# Altium schematic sheet 02_OCDS.SchDoc(02_OCDS)
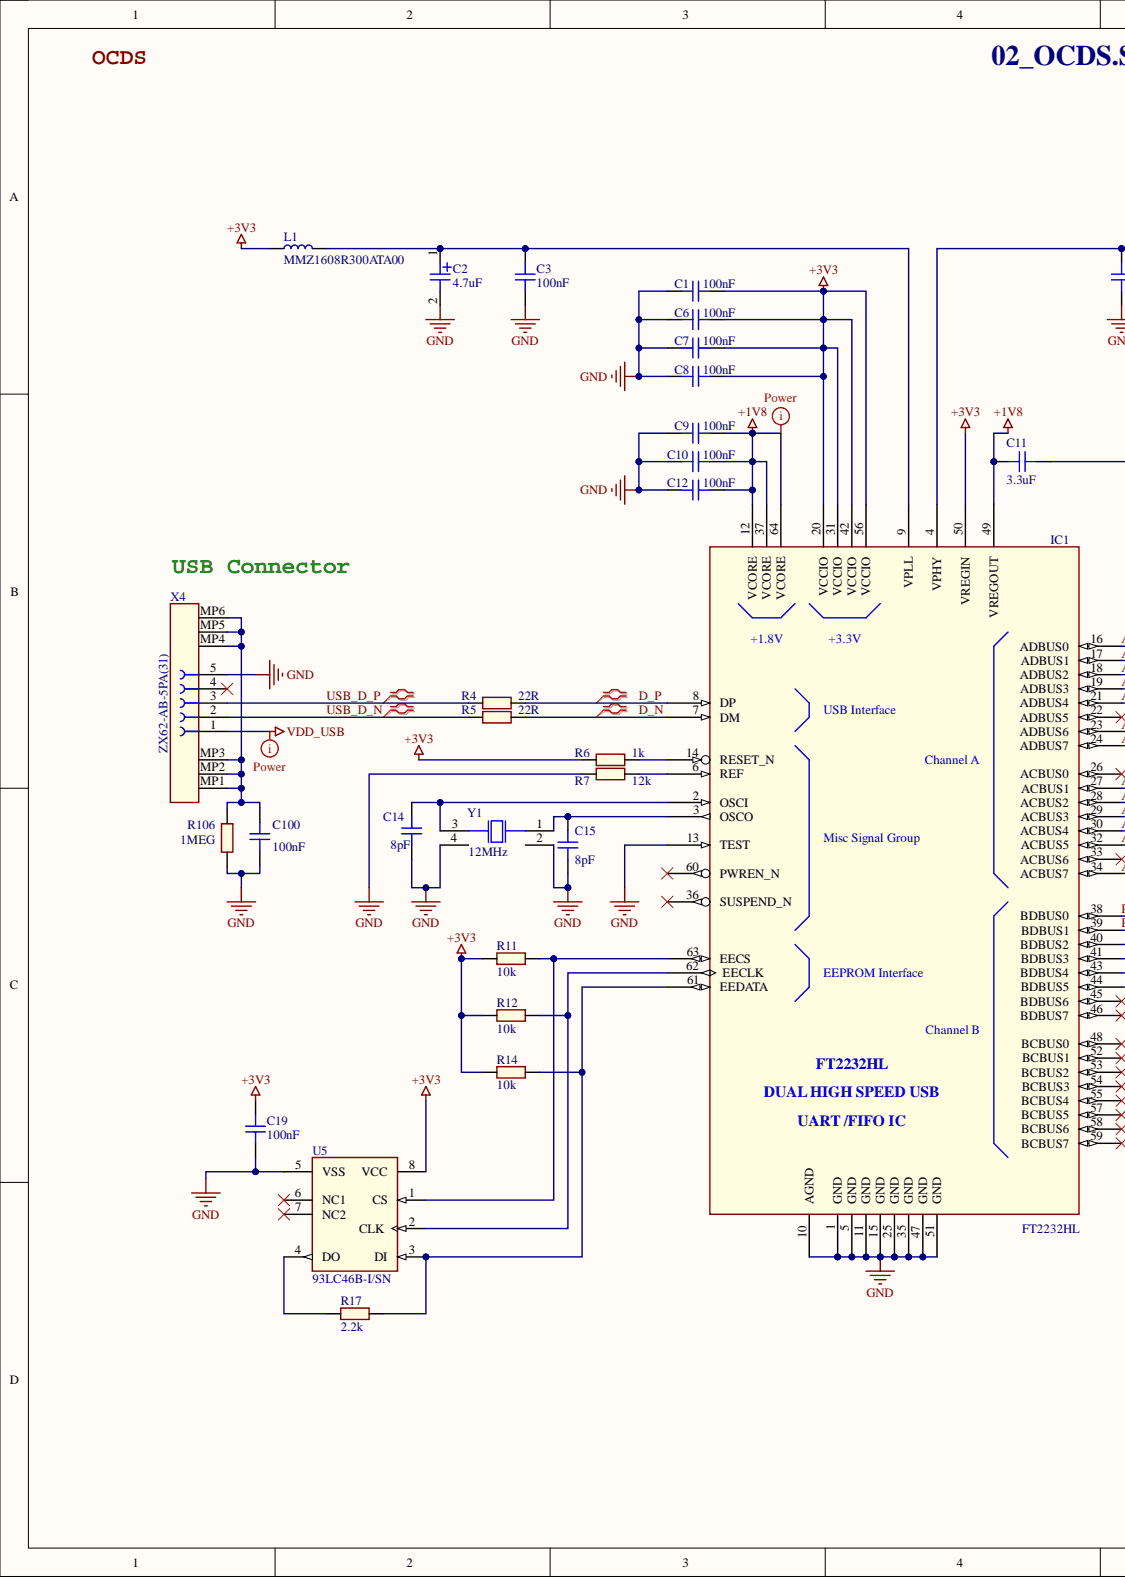
[diagram p0: 02_OCDS.SchDoc, left]
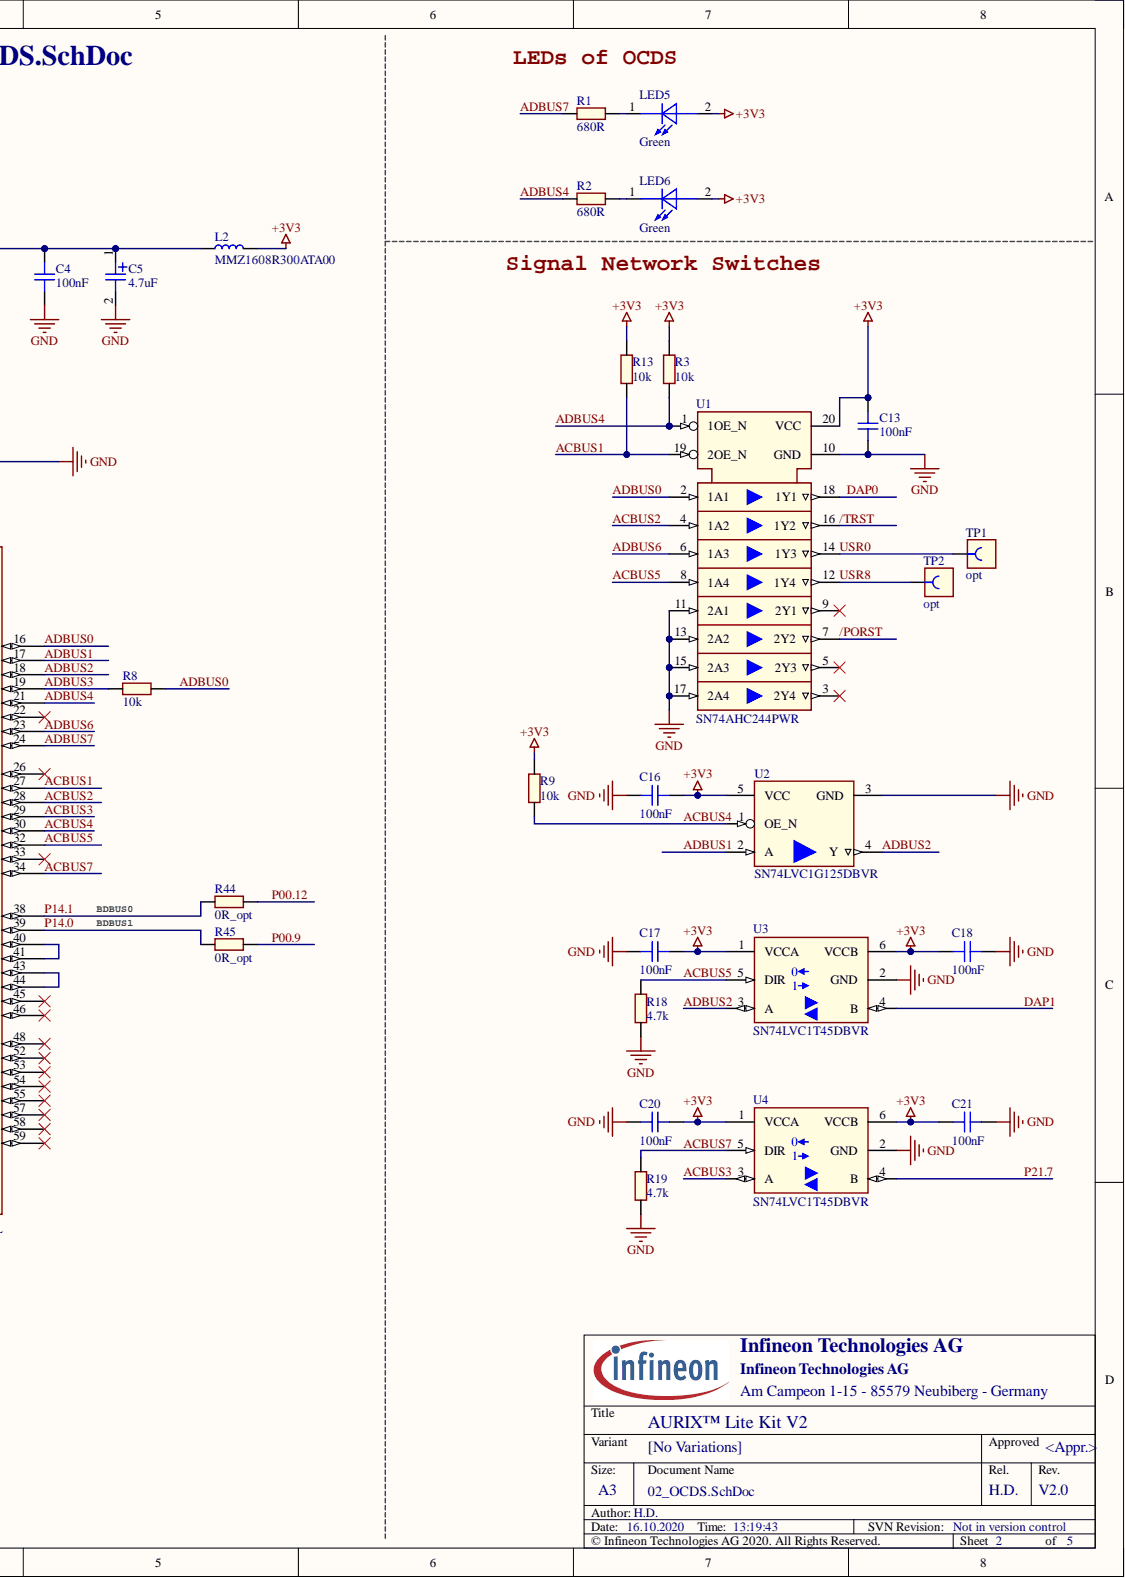
[diagram p1: 02_OCDS.SchDoc, right]

## Parts
C1  100nF  [CAPC1005X55N-1]
C2  4.7uF  [CAPMP3216X180N]
C3  100nF  [CAPC1005X55N-1]
C4  100nF  [CAPC1005X55N-1]
C5  4.7uF  [CAPMP3216X180N]
C6  100nF  [CAPC1005X55N-1]
C7  100nF  [CAPC1005X55N-1]
C8  100nF  [CAPC1005X55N-1]
C9  100nF  [CAPC1005X55N-1]
C10  100nF  [CAPC1005X55N-1]
C11  3.3uF  [CAPC2013X95N]
C12  100nF  [CAPC1005X55N-1]
C13  100nF  [CAPC1005X55N-1]
C14  8pF  [CAPC1005X55N-0]
C15  8pF  [CAPC1005X55N-0]
C16  100nF  [CAPC1005X55N-1]
C17  100nF  [CAPC1005X55N-1]
C18  100nF  [CAPC1005X55N-1]
C19  100nF  [CAPC1005X55N-1]
C20  100nF  [CAPC1005X55N-1]
C21  100nF  [CAPC1005X55N-1]
C100  100nF  [CAPC1005X55N-1]
IC1  FT2232HL  [QFP50P1200X1200X160-64N]
L1  MMZ1608R300ATA00  [INDC1608X95N_MMZ1608]
L2  MMZ1608R300ATA00  [INDC1608X95N_MMZ1608]
LED5  Green  [LED-SMD-LG L29K-XXXX-24]
LED6  Green  [LED-SMD-LG L29K-XXXX-24]
R1  680R  [RESC1608X55N-1]
R2  680R  [RESC1608X55N-1]
R3  10k  [RESC1005X40N]
R4  22R  [RESC1005X40N]
R5  22R  [RESC1005X40N]
R6  1k  [RESC1005X40N-1]
R7  12k  [RESC1005X40N]
R8  10k  [RESC1005X40N]
R9  10k  [RESC1005X40N]
R11  10k  [RESC1005X40N]
R12  10k  [RESC1005X40N]
R13  10k  [RESC1005X40N]
R14  10k  [RESC1005X40N]
R17  2.2k  [RESC1005X40N]
R18  4.7k  [RESC1005X40N-1]
R19  4.7k  [RESC1005X40N-1]
R44  0R_opt  [RESC1609X50N]
R45  0R_opt  [RESC1609X50N]
R106  1MEG  [RESC1005X40N]
TP1  opt  [TPCW100D120H460B]
TP2  opt  [TPCW100D120H460B]
U1  SN74AHC244PWR  [SOP65P640X120-20N]
U2  SN74LVC1G125DBVR  [SOT95P280X145-5N-2]
U3  SN74LVC1T45DBVR  [SOT95P280X145-6N-6]
U4  SN74LVC1T45DBVR  [SOT95P280X145-6N-6]
U5  93LC46B-I/SN  [SOIC127P600X175-8N-1]
X4  ZX62-AB-5PA(31)  Part Type: Connector  Style: USB  [CON-USB-SMD-ZX62-AB-5PA(31)]
Y1  12MHz  [XTAL-SMD-NX3225SA]

## Nets
+1V8: C9.2 C10.2 C11.2 C12.2 IC1.12(VCORE) IC1.37(VCORE) IC1.49(VREGOUT) IC1.64(VCORE)
+3V3: C1.2 C6.2 C7.2 C8.2 C13.2 C16.2 C17.2 C18.2 C19.2 C20.2 C21.2 IC1.20(VCCIO) IC1.31(VCCIO) IC1.42(VCCIO) IC1.50(VREGIN) IC1.56(VCCIO) L1.1 L2.2 LED5.2 LED6.2 R3.2 R6.2 R9.2 R11.2 R12.2 R13.2 R14.2 U1.20(VCC) U2.5(VCC) U3.1(VCCA) U3.6(VCCB) U4.1(VCCA) U4.6(VCCB) U5.8(VCC)
GND: C1.1 C2.2 C3.1 C4.1 C5.2 C6.1 C7.1 C8.1 C9.1 C10.1 C11.1 C12.1 C13.1 C14.2 C15.2 C16.1 C17.1 C18.1 C19.1 C20.1 C21.1 C100.1 IC1.1(GND) IC1.5(GND) IC1.10(AGND) IC1.11(GND) IC1.13(TEST) IC1.15(GND) IC1.25(GND) IC1.35(GND) IC1.47(GND) IC1.51(GND) R7.1 R18.1 R19.1 R106.2 U1.10(GND) U1.11(2A1) U1.13(2A2) U1.15(2A3) U1.17(2A4) U2.3(GND) U3.2(GND) U4.2(GND) U5.5(VSS) X4.5 Y1.2 Y1.4
VDD_USB: X4.1
/PORST: U1.7(2Y2)
/TRST: U1.16(1Y2)
ACBUS1: IC1.27(ACBUS1) R13.1 U1.19(2OE_N)
ACBUS2: IC1.28(ACBUS2) U1.4(1A2)
ACBUS3: IC1.29(ACBUS3) U4.3(A)
ACBUS4: IC1.30(ACBUS4) R9.1 U2.1(OE_N)
ACBUS5: IC1.32(ACBUS5) R18.2 U1.8(1A4) U3.5(DIR)
ACBUS7: IC1.34(ACBUS7) R19.2 U4.5(DIR)
ADBUS0: IC1.16(ADBUS0) R8.1 U1.2(1A1)
ADBUS1: IC1.17(ADBUS1) U2.2(A)
ADBUS2: IC1.18(ADBUS2) U2.4(Y) U3.3(A)
ADBUS3: IC1.19(ADBUS3) R8.2
ADBUS4: IC1.21(ADBUS4) R2.1 R3.1 U1.1(1OE_N)
ADBUS6: IC1.23(ADBUS6) U1.6(1A3)
ADBUS7: IC1.24(ADBUS7) R1.1
D_N: IC1.7(DM) R5.2
D_P: IC1.8(DP) R4.2
DAP0: U1.18(1Y1)
DAP1: U3.4(B)
P00.9: R45.2
P00.12: R44.2
P14.0: IC1.39(BDBUS1) R45.1
P14.1: IC1.38(BDBUS0) R44.1
P21.7: U4.4(B)
USB_D_N: R5.1 X4.2
USB_D_P: R4.1 X4.3
USR0: TP1.1 U1.14(1Y3)
USR8: TP2.1 U1.12(1Y4)
NetC2_1: C2.1 C3.2 IC1.9(VPLL) L1.2
NetC4_2: C4.2 C5.1 IC1.4(VPHY) L2.1
NetC14_1: C14.1 IC1.2(OSCI) Y1.3
NetC15_1: C15.1 IC1.3(OSCO) Y1.1
NetC100_2: C100.2 R106.1 X4.MP1 X4.MP2 X4.MP3 X4.MP4 X4.MP5 X4.MP6
NetIC1_6: IC1.6(REF) R7.2
NetIC1_14: IC1.14(RESET_N) R6.1
NetIC1_40: IC1.40(BDBUS2) IC1.41(BDBUS3)
NetIC1_43: IC1.43(BDBUS4) IC1.44(BDBUS5)
NetIC1_61: IC1.61(EEDATA) R14.1 R17.2 U5.3(DI)
NetIC1_62: IC1.62(EECLK) R12.1 U5.2(CLK)
NetIC1_63: IC1.63(EECS) R11.1 U5.1(CS)
NetLED5_1: LED5.1 R1.2
NetLED6_1: LED6.1 R2.2
NetR17_1: R17.1 U5.4(DO)

Not connected: IC1 22(ADBUS5) 26(ACBUS0) 33(ACBUS6) 36(SUSPEND_N) 45(BDBUS6) 46(BDBUS7) 48(BCBUS0) 52(BCBUS1) 53(BCBUS2) 54(BCBUS3) 55(BCBUS4) 57(BCBUS5) 58(BCBUS6) 59(BCBUS7) 60(PWREN_N); U1 3(2Y4) 5(2Y3) 9(2Y1); U5 6(NC1) 7(NC2); X4 4

## Library data of the parts
C1 C3 C4 C6-C10 C12 C13 C16-C21 C100 = 308680: Multilayer Ceramic Chip Capacitor; Manufacturer: TDK Corporation; Manufacturer Component Status: Active; Manufacturer Order Number: CGA2B1X7R1C104K050BC; Multiple Parts: No; Alternate View: Yes; Automotive Qualified: AEC Q200; Combined Parameters: TC:X7R (EIA);Tol.:-10%/+10%;Vdc:16V; Legacy DeviceID: CAP01010669; Package Imperial: 0402; Package Metric: 1005; QuickPick: 100nF / 16V / 10% / X7R (EIA) / 0402 (1005); Style: Single; Symbol Type: Capacitor; Single; Standard; 2 Pins; Tolerance Neg: 10%; Type: Standard; Version Number: V01; Voltage DC (max): 16V; Article Number: 308680; Component Kind: Standard; ComponentLink1Description: Vendor WebSite; ComponentLink1URL: http://www.tdk.com; ComponentLink2Description: Datasheet; ComponentLink2URL: http://webnetprod.muc.infineon.com/PCBPortal_Prod/WorkFlows/AltiumComponent/StandardDocumentation?Versionid=308681; Legacy PartNumber: CAP01010669; Package Description: Capacitor,non-polarized,Chip;1.00mm L X 0.50mm W X 0.55mm H; PackageReference: 0402 (1005); Part Type: Capacitor; PLMStatus: Active; ROHS Compliant: Y; Second Source allowed: Yes; Supplier 1: Farnell; Supplier 2: DigiKey; Supplier 3: Mouser; Supplier Part Number 1: 2458205; Supplier Part Number 2: 445-5613-2-ND; Supplier Part Number 3: 810-CGA2B1X7R1C104K; Technology: Ceramic; Temperature max: 125; Temperature min: -55; Temperature Range: Military; Tolerance Pos: 10%; Value: 100nF; Library Name: AURIX_Lite_Kit_V2.0.SCHLIB
C2 C5 = 307693: Surface Mount Tantalum Molded Capacitor; Manufacturer: Kemet; Manufacturer Component Status: Active; Manufacturer Order Number: T491A475K010AT; Alternate View: Yes; Automotive Qualified: No; Combined Parameters: TC:Tantalumelectrolytic;Tol.:-10%/+10%;Vdc:10V; Legacy DeviceID: CAP01010557; QuickPick: 4.7uF / 10V / 10% / Tantalumelectrolytic / CAPMP3216X180N; Style: Single; Symbol Type: Capacitor; Single; Polarized; 2 Pins; Pin 1 Positive; Tolerance Neg: 10%; Type: Standard; Version Number: V01; Voltage DC (max): 10V; Article Number: 307693; Component Kind: Standard; ComponentLink1Description: Vendor WebSite; ComponentLink1URL: http://www.kemet.com; ComponentLink2Description: Datasheet; ComponentLink2URL: http://webnetprod.muc.infineon.com/PCBPortal_Prod/WorkFlows/AltiumComponent/StandardDocumentation?Versionid=307694; Legacy PartNumber: CAP01010557; Multible Parts: No; Package Description: Capacitor,polarized,Molded Body;3.20mm L X 1.60mm W X 1.80mm H; PackageReference: CAPMP3216X180N; Part Type: Capacitor; PLMStatus: Active; ROHS Compliant: Y; Second Source allowed: Yes; Supplier 1: Farnell; Supplier 2: DigiKey; Supplier 3: Mouser; Supplier Part Number 1: 1457427; Supplier Part Number 2: 399-3696-2-ND; Supplier Part Number 3: 80-T491A475K010; Technology: Electrolytic; Temperature max: 125; Temperature min: -55; Temperature Range: Military; Tolerance Pos: 10%; Value: 4.7uF; Library Name: AURIX_Lite_Kit_V2.0.SCHLIB
C11 = 277032: Chip Monolithic Ceramic Capacitor; Manufacturer: MuRata; Manufacturer Component Status: Active; Manufacturer Order Number: GRM219R61A335KE19; Alternate View: Yes; Automotive Qualified: No; Combined Parameters: TC:X5R (EIA);Tol.:-10%/+10%;Vdc:10V; Legacy DeviceID: CAP01004421; Package Imperial: 0805; Package Metric: 2012; QuickPick: 3.3uF / 10V / 10% / X5R (EIA) / CAPC2013X95N; Style: Single; Symbol Type: Capacitor; Single; Standard; 2 Pins; Tolerance Neg: 10%; Type: Standard; Version Number: V01; Voltage DC (max): 10V; Application: Standard; Article Number: 277032; Component Kind: Standard; ComponentLink1Description: Vendor WebSite; ComponentLink1URL: http://www.murata.com/; ComponentLink2Description: Datasheet; ComponentLink2URL: http://webnetprod.muc.infineon.com/PCBPortal_Prod/WorkFlows/AltiumComponent/StandardDocumentation?Versionid=277033; Legacy PartNumber: CAP01004421; Multiple Parts: No; Package Description: Capacitor,non-polarized,Chip;2.00mm L X 1.25mm W X 0.95mm H; PackageReference: CAPC2013X95N; Part Type: Capacitor; PLMStatus: Active; ROHS Compliant: Y; Second Source allowed: Yes; Supplier 1: Farnell; Supplier 2: DigiKey; Supplier 3: Mouser; Technology: Ceramic; Temperature max: 85; Temperature min: -55; Temperature Range: Military; Tolerance Pos: 10%; Value: 3.3uF; Library Name: AURIX_Lite_Kit_V2.0.SCHLIB
C14 C15 = 288376: Chip Monolithic Ceramic Capacitor; Manufacturer: MuRata; Manufacturer Component Status: Active; Manufacturer Order Number: GCM1555C1H8R0WA16; Multiple Parts: No; Alternate View: Yes; Automotive Qualified: AEC Q200; Combined Parameters: TC:C0G (EIA) / NP0;Tol.:-5fF/+5fF;Vdc:50V; Legacy DeviceID: CAP01006748; Package Imperial: 0402; Package Metric: 1005; QuickPick: 8pF / 50V / 5fF / C0G (EIA) / NP0 / CAPC1005X55N-0; Style: Single; Symbol Type: Capacitor; Single; Standard; 2 Pins; Tolerance Neg: 5fF; Type: Standard; Version Number: V01; Voltage DC (max): 50V; Application: Standard; Article Number: 288376; Component Kind: Standard; ComponentLink1Description: Vendor WebSite; ComponentLink1URL: http://www.murata.com/; ComponentLink2Description: Datasheet; ComponentLink2URL: http://webnetprod.muc.infineon.com/PCBPortal_Prod/WorkFlows/AltiumComponent/StandardDocumentation?Versionid=288377; Legacy PartNumber: CAP01006748; Package Description: Capacitor,non-polarized,Chip;1.00mm L X 0.50mm W X 0.55mm H; PackageReference: CAPC1005X55N-0; Part Type: Capacitor; PLMStatus: Active; ROHS Compliant: Y; Second Source allowed: Yes; Supplier 1: Farnell; Supplier 2: DigiKey; Supplier 3: Mouser; Technology: Ceramic; Temperature max: 125; Temperature min: -55; Temperature Range: Military; Tolerance Pos: 5fF; Value: 8pF; Library Name: AURIX_Lite_Kit_V2.0.SCHLIB
IC1 = 403172: Dual High Speed USB to Multipurpose UART/FIFO IC; Legacy PartNumber: IC02600036; Manufacturer: Future Technology Devices International; Manufacturer Component Status: Active; Automotive Qualified: No; Combined Parameters: Standard Interface Interface/Transceiver;Vdc min/max:3V/3.6V;Iout:16mA;Fmax:12MHz; Legacy DeviceID: FTDI_FT2232HL; QuickPick: LQFP-64; Second Source allowed: Yes; Version Number: V01; Voltage DC (max): na; Application: Standard Interface; Article Number: 403172; Component Kind: Standard; ComponentLink1Description: Vendor WebSite; ComponentLink1URL: http://www.ftdichip.com/; ComponentLink2Description: Datasheet; ComponentLink2URL: http://webnetprod.muc.infineon.com/PCBPortal_Prod/WorkFlows/AltiumComponent/StandardDocumentation?Versionid=403173; Manufacturer Order Number: FT2232HL; Package Description: QFP,0.50mm pitch,square;64 pin,10.00mm L X 10.00mm W X 1.60mm H body; PackageReference: LQFP-64; Part Type: Integrated Circuit; PLMStatus: Active; ROHS Compliant: Y; Supplier 1: Farnell; Supplier 2: DigiKey; Supplier 3: Mouser; Temperature max: 85; Temperature min: -40; Temperature Range: Industrial; Type: Interface/Transceiver; Value: FT2232HL; Library Name: AURIX_Lite_Kit_V2.0.SCHLIB
L1 L2 = 326212: Chip Bead For Signal Line; Manufacturer: TDK Corporation; Manufacturer Component Status: Active; Alternate View: Yes; Automotive Qualified: No; Combined Parameters: Tol.:-25%/+25%;Rdc:-;Idc:1.5A;Fres:-; Legacy DeviceID: IND00702701; Package Imperial: 0603; Package Metric: 1608; Symbol Type: Inductor; Single; Standard; 2 Pins; Tolerance Neg: 25%; Version Number: V01; Voltage DC (max): na; Article Number: 326212; Component Kind: Standard; ComponentLink1Description: Vendor WebSite; ComponentLink1URL: http://www.tdk.com; ComponentLink2Description: Datasheet; ComponentLink2URL: http://webnetprod.muc.infineon.com/PCBPortal_Prod/WorkFlows/AltiumComponent/StandardDocumentation?Versionid=326213; Legacy PartNumber: IND00702701; Manufacturer Order Number: MMZ1608R300ATA00; Multiple Parts: No; Package Description: Inductor,Chip;1.60mm L X 0.80mm W X 0.95mm H; PackageReference: 1608; Part Type: Inductor; PLMStatus: Active; QuickPick: 1608; Second Source allowed: Yes; Supplier 1: Farnell; Supplier 2: DigiKey; Supplier 3: Mouser; Supplier Part Number 1: 2137003; Supplier Part Number 2: 445-2181-2-ND; Supplier Part Number 3: 810-MMZ1608R300ATA00; Temperature Range: Military; Tolerance Pos: 25%; Library Name: AURIX_Lite_Kit_V2.0.SCHLIB
LED5 LED6 = 395008: Surface Mount LED, Green, 570nm; Manufacturer: OSRAM Opto Semiconductors; Manufacturer Component Status: Active; Manufacturer Order Number: LG L29K-G2J1-24; Automotive Qualified: No; Combined Parameters: Vf:2.2V;If:20mA;Ur:12V;Ir:10nA;Pmax:-; Legacy DeviceID: DIO01300294; QuickPick: LED / 0603; Second Source allowed: Yes; Style: Single; Symbol Type: Standard LED Symbol, 1 Cathode, 2 Anode; Version Number: V01; Voltage DC (max): na; Application: Standard; Article Number: 395008; Component Kind: Standard; ComponentLink1Description: Vendor WebSite; ComponentLink1URL: http://www.osram-os.com; ComponentLink2Description: Datasheet; ComponentLink2URL: http://webnetprod.muc.infineon.com/PCBPortal_Prod/WorkFlows/AltiumComponent/StandardDocumentation?Versionid=395009; Legacy PartNumber: DIO01300294; Package Description: LED;2 pin,1.3mm W X 0.80mm L X 0.67mm H body; PackageReference: 0603; Part Type: Diode; PLMStatus: Active; ROHS Compliant: Y; Supplier 1: Farnell; Supplier 2: DigiKey; Supplier 3: Mouser; Supplier Part Number 2: 475-2709-2-ND; Supplier Part Number 3: 720-LGL29KG2J124Z; Temperature max: 100; Temperature min: -40; Temperature Range: Industrial; Type: LED; Value: Green; Library Name: AURIX_Lite_Kit_V2.0.SCHLIB
R1 R2 = 327766_NEW: General Purpose Chip Resistor; Manufacturer: Yageo; Manufacturer Component Status: Active; Manufacturer Order Number: RC0603FR-07680RL; Alternate View: Yes; Article Number: 327766; Automotive Qualified: No; Combined Parameters: TCR:100ppm/K;Tol.:-1%/+1%;Vdc:75V;Idc:-;P:100mW; Legacy DeviceID: RES00000077; QuickPick: 680R / 1% / 0.10 / 0603; Style: Single; Symbol Type: Resistor; Single; Standard; 2 Pins; Tolerance Neg: 1%; Version Number: V01; Voltage DC (max): 75V; Component Kind: Standard; ComponentLink1Description: Vendor WebSite; ComponentLink1URL: http://www.yageo.com/; ComponentLink2Description: Datasheet; ComponentLink2URL: http://webnetprod.muc.infineon.com/PCBPortal_Prod/WorkFlows/AltiumComponent/StandardDocumentation?Versionid=327767; Legacy PartNumber: RES00000077; Multiple Parts: No; PackageReference: 0603; Part Type: Resistor; PLMStatus: Active; ROHS Compliant: Y; Second Source allowed: Yes; Supplier 1: Farnell; Supplier 2: DigiKey; Supplier 3: Mouser; Supplier Part Number 1: 9238468; Supplier Part Number 2: 311-680HRTR-ND; Supplier Part Number 3: 603-RC0603FR-07680RL; Technology: Thick Film; Temperature max: 155; Temperature min: -55; Temperature Range: Military; Tolerance Pos: 1%; Type: Standard; Value: 680R; Library Name: AURIX_Lite_Kit_V2.0.SCHLIB
R3 R8 R9 R11-R14 = 342167: Standard Thick Film Chip Resistor; Manufacturer: Vishay; Manufacturer Component Status: Active; Manufacturer Order Number: CRCW040210K0FK; Multiple Parts: No; Alternate View: Yes; Automotive Qualified: AEC Q200; Combined Parameters: TCR:100ppm/K;Tol.:-1%/+1%;Vdc:50V;Idc:-;P:63mW; Legacy DeviceID: RES01800457; QuickPick: 10k / 1% / 0.0630 / 0402; Style: Single; Symbol Type: Resistor; Single; Standard; 2 Pins; Tolerance Neg: 1%; Version Number: V01; Voltage DC (max): 50V; Article Number: 342167; Component Kind: Standard; ComponentLink1Description: Vendor WebSite; ComponentLink1URL: http://www.vishay.com; ComponentLink2Description: Datasheet; ComponentLink2URL: http://webnetprod.muc.infineon.com/PCBPortal_Prod/WorkFlows/AltiumComponent/StandardDocumentation?Versionid=342168; Legacy PartNumber: RES01800457; PackageReference: 0402; Part Type: Resistor; PLMStatus: Active; ROHS Compliant: Y; Second Source allowed: Yes; Supplier 1: Farnell; Supplier 2: DigiKey; Supplier 3: Mouser; Technology: Thick Film; Temperature max: 155; Temperature min: -55; Temperature Range: Military; Tolerance Pos: 1%; Type: Standard; Value: 10k; Library Name: AURIX_Lite_Kit_V2.0.SCHLIB
R4 R5 = 340637: Standard Thick Film Chip Resistor; Manufacturer: Vishay; Manufacturer Component Status: Active; Manufacturer Order Number: CRCW040222R0FK; Multiple Parts: No; Alternate View: Yes; Automotive Qualified: AEC Q200; Combined Parameters: TCR:100ppm/K;Tol.:-1%/+1%;Vdc:50V;Idc:-;P:63mW; Legacy DeviceID: RES01800151; Style: Single; Symbol Type: Resistor; Single; Standard; 2 Pins; Tolerance Neg: 1%; Version Number: V01; Voltage DC (max): 50V; Article Number: 340637; Component Kind: Standard; ComponentLink1Description: Vendor WebSite; ComponentLink1URL: http://www.vishay.com; ComponentLink2Description: Datasheet; ComponentLink2URL: http://webnetprod.muc.infineon.com/pcbportal_prod/WorkFlows/AltiumComponent/StandardDocumentation?Versionid=340638; Legacy PartNumber: RES01800151; PackageReference: 0402; Part Type: Resistor; PLMStatus: Active; RoHS Compliant: Y; Second Source allowed: Yes; Supplier 1: Farnell; Supplier 2: DigiKey; Supplier 3: Mouser; Technology: Thick Film; Temperature max: 155; Temperature min: -55; Temperature Range: Military; Tolerance Pos: 1%; Type: Standard; Value: 22R; Library Name: AURIX_Lite_Kit_V2.0.SCHLIB
R6 = 370987: 1k/50V/5%; Manufacturer: Yageo; Manufacturer Component Status: Active; Manufacturer Order Number: RC0402JR-131KL; Alternate View: Yes; Automotive Qualified: No; Combined Parameters: TCR:100ppm/K;Tol.:-5%/+5%;Vdc:50V;Idc:-;P:62.5mW; Legacy DeviceID: RES01806043; QuickPick: 1k / 5% / 0.0625 / 0402; Style: Single; Symbol Type: Resistor; Single; Standard; 2 Pins; Tolerance Neg: 5%; Version Number: V01; Voltage DC (max): 50V; Article Number: 370987; Component Kind: Standard; ComponentLink1Description: Vendor WebSite; ComponentLink1URL: http://www.yageo.com/; ComponentLink2Description: Datasheet; ComponentLink2URL: http://webnetprod.muc.infineon.com/PCBPortal_Prod/WorkFlows/AltiumComponent/StandardDocumentation?Versionid=370988; Legacy PartNumber: RES01806043; Multiple Parts: No; PackageReference: 0402; Part Type: Resistor; PLMStatus: Active; ROHS Compliant: Y; Second Source allowed: Yes; Supplier 1: Farnell; Supplier 2: DigiKey; Supplier 3: Mouser; Supplier Part Number 1: 1799315; Technology: Thick Film; Temperature max: 155; Temperature min: -55; Temperature Range: Military; Tolerance Pos: 5%; Type: Standard; Value: 1k; Library Name: AURIX_Lite_Kit_V2.0.SCHLIB
R7 = 342207: Standard Thick Film Chip Resistor; Manufacturer: Vishay; Manufacturer Component Status: Active; Manufacturer Order Number: CRCW040212K0FK; Multiple Parts: No; Alternate View: Yes; Automotive Qualified: AEC Q200; Combined Parameters: TCR:100ppm/K;Tol.:-1%/+1%;Vdc:50V;Idc:-;P:63mW; Legacy DeviceID: RES01800465; QuickPick: 12k / 1% / 0.0630 / 0402; Style: Single; Symbol Type: Resistor; Single; Standard; 2 Pins; Tolerance Neg: 1%; Version Number: V01; Voltage DC (max): 50V; Article Number: 342207; Component Kind: Standard; ComponentLink1Description: Vendor WebSite; ComponentLink1URL: http://www.vishay.com; ComponentLink2Description: Datasheet; ComponentLink2URL: http://webnetprod.muc.infineon.com/PCBPortal_Prod/WorkFlows/AltiumComponent/StandardDocumentation?Versionid=342208; Legacy PartNumber: RES01800465; PackageReference: 0402; Part Type: Resistor; PLMStatus: Active; ROHS Compliant: Y; Second Source allowed: Yes; Supplier 1: Farnell; Supplier 2: DigiKey; Supplier 3: Mouser; Technology: Thick Film; Temperature max: 155; Temperature min: -55; Temperature Range: Military; Tolerance Pos: 1%; Type: Standard; Value: 12k; Library Name: AURIX_Lite_Kit_V2.0.SCHLIB
R17 = 341777: Standard Thick Film Chip Resistor; Manufacturer: Vishay; Manufacturer Component Status: Active; Manufacturer Order Number: CRCW04022K20FK; Multiple Parts: No; Alternate View: Yes; Automotive Qualified: AEC Q200; Combined Parameters: TCR:100ppm/K;Tol.:-1%/+1%;Vdc:50V;Idc:-;P:63mW; ComponentStatus: Released; Legacy DeviceID: RES01800379; QuickPick: 2.2k / 1% / 0.0630 / 0402; Style: Single; Symbol Type: Resistor; Single; Standard; 2 Pins; Tolerance Neg: 1%; Version Number: V01; Voltage DC (max): 50V; Article Number: 341777; Component Kind: Standard; ComponentLink1Description: Vendor WebSite; ComponentLink1URL: http://www.vishay.com; ComponentLink2Description: Datasheet; ComponentLink2URL: http://webnetprod.muc.infineon.com/PCBPortal_Prod/WorkFlows/AltiumComponent/StandardDocumentation?Versionid=341778; Legacy PartNumber: RES01800379; PackageReference: 0402; Part Type: Resistor; PLMStatus: Active; ROHS Compliant: Y; Second Source allowed: Yes; Supplier 1: Farnell; Supplier 2: DigiKey; Supplier 3: Mouser; Technology: Thick Film; Temperature max: 155; Temperature min: -55; Temperature Range: Military; Tolerance Pos: 1%; Type: Standard; Value: 2.2k; Library Name: AURIX_Lite_Kit_V2.0.SCHLIB
R18 R19 = 327541: General Purpose Chip Resistor; Manufacturer: Yageo; Manufacturer Component Status: Active; Manufacturer Order Number: RC0402FR-074K7L; Alternate View: Yes; Automotive Qualified: No; Combined Parameters: TCR:100ppm/K;Tol.:-1%/+1%;Vdc:50V;Idc:-;P:63mW; Package Imperial: 0402; Package Metric: 1005; QuickPick: 4.7k / 1% / 0.0630 / 0402; Style: Single; Symbol Type: Resistor; Single; Standard; 2 Pins; Tolerance Neg: 1%; Version Number: V01; Voltage DC (max): 50V; Application: Standard; Article Number: 327541; Component Kind: Standard; ComponentLink1Description: Vendor WebSite; ComponentLink1URL: http://www.yageo.com/; ComponentLink2Description: Datasheet; ComponentLink2URL: http://webnetprod.muc.infineon.com/PCBPortal_Prod/WorkFlows/AltiumComponent/StandardDocumentation?Versionid=327542; Multiple Parts: No; Package Description: Resistor,Chip;1.00mm L X 0.50mm W X 0.40mm H; PackageReference: 0402; Part Type: Resistor; PLMStatus: Active; ROHS Compliant: Y; Second Source allowed: Yes; Supplier 1: Farnell; Supplier 2: DigiKey; Supplier 3: Mouser; Supplier Part Number 1: 9239316; Supplier Part Number 2: 311-4.7KLRTR-ND; Supplier Part Number 3: 603-RC0402FR-074K7L; Technology: Thick Film; Temperature max: 155; Temperature min: -55; Temperature Range: Military; Tolerance Pos: 1%; Type: Standard; Value: 4.7k; Library Name: AURIX_Lite_Kit_V2.0.SCHLIB
R44 R45 = 343882: Standard Thick Film Chip Resistor; Manufacturer: Vishay; Manufacturer Order Number: CRCW06030000Z0; Multiple Parts: No; Alternate View: Yes; Automotive Qualified: AEC Q200; Combined Parameters: TCR:-;Tol.:-0R/+0R;Vdc:75V;Idc:2A;P:-; Legacy DeviceID: RES01800800; PLMStatus: Active; QuickPick: 0R / 0R / 0603; Style: Single; Symbol Type: Resistor; Single; Standard; 2 Pins; Tolerance Neg: 0R; Value: 0R; Version Number: V01; Voltage DC (max): 75V; Article Number: 343882; Component Kind: Standard; ComponentLink1Description: Vendor WebSite; ComponentLink1URL: http://www.vishay.com; ComponentLink2Description: Datasheet; ComponentLink2URL: http://webnetprod.muc.infineon.com/PCBPortal_Prod/WorkFlows/AltiumComponent/StandardDocumentation?Versionid=343883; Legacy PartNumber: RES01800800; PackageReference: 0603; Part Type: Resistor; ROHS Compliant: Y; Second Source allowed: Yes; Supplier 1: Farnell; Supplier 2: DigiKey; Supplier 3: Mouser; Supplier Part Number 2: 541-0.0GCT-ND; Technology: Thick Film; Temperature max: 155; Temperature min: -55; Temperature Range: Military; Tolerance Pos: 0R; Type: Standard; Library Name: AURIX_Lite_Kit_V2.0.SCHLIB
R106 = 343307: Standard Thick Film Chip Resistor; Manufacturer: Vishay; Manufacturer Component Status: Active; Manufacturer Order Number: CRCW04021M00FK; Multiple Parts: No; Alternate View: Yes; Automotive Qualified: AEC Q200; Combined Parameters: TCR:100ppm/K;Tol.:-1%/+1%;Vdc:50V;Idc:-;P:63mW; Legacy DeviceID: RES01800685; Style: Single; Symbol Type: Resistor; Single; Standard; 2 Pins; Tolerance Neg: 1%; Version Number: V01; Voltage DC (max): 50V; Article Number: 343307; Component Kind: Standard; ComponentLink1Description: Vendor WebSite; ComponentLink1URL: http://www.vishay.com; ComponentLink2Description: Datasheet; ComponentLink2URL: http://webnetprod.muc.infineon.com/pcbportal_prod/WorkFlows/AltiumComponent/StandardDocumentation?Versionid=343308; Legacy PartNumber: RES01800685; PackageReference: 0402; Part Type: Resistor; PLMStatus: Active; RoHS Compliant: Y; Second Source allowed: Yes; Supplier 1: Farnell; Supplier 2: DigiKey; Supplier 3: Mouser; Supplier Part Number 1: 2121984; Technology: Thick Film; Temperature max: 155; Temperature min: -55; Temperature Range: Military; Tolerance Pos: 1%; Type: Standard; Value: 1MEG; Library Name: AURIX_Lite_Kit_V2.0.SCHLIB
TP1 TP2 = 377411: Black bead terminal assembly 1.02mm hole; Legacy PartNumber: CON01500046; Manufacturer: Vero Technologies; Manufacturer Order Number: 20-2137; Alternate View: Yes; Automotive Qualified: No; Combined Parameters: Board-to-Cable None (onboard);1x1;Mounting:THT;Orient:None (onboard);Shielded:No; Legacy DeviceID: VER_TESTPOINT_PTH; PLMStatus: Active; QuickPick: None (onboard) / Board-to-Cable; Style: Looped Terminal; Symbol Type: Connector, 1 Lead, Female; Value: 20-2137; Version Number: V01; Voltage DC (max): na; Application: Test; Article Number: 377411; Component Kind: Standard; ComponentLink1Description: Vendor WebSite; ComponentLink1URL: http://www.verotl.com; ComponentLink2Description: Datasheet; ComponentLink2URL: http://webnetprod.muc.infineon.com/PCBPortal_Prod/WorkFlows/AltiumComponent/StandardDocumentation?Versionid=377412; Multiple Parts: No; Package Description: Test Point, 1.00mm lead width,round,1.2mm Dia X 4.60mm H body; Part Type: Connector; ROHS Compliant: N; Second Source allowed: Yes; Supplier 1: Farnell; Supplier 2: DigiKey; Supplier 3: Mouser; Supplier Part Number 1: 8731128; Temperature Range: Military; Type: Board-to-Cable; Library Name: AURIX_Lite_Kit_V2.0.SCHLIB
U1 = 408890: Octal Buffer/Driver with 3-State Outputs; Legacy PartNumber: IC02600552; Manufacturer: Texas Instruments; Manufacturer Component Status: Active; Automotive Qualified: No; ComponentStatus: Released; Legacy DeviceID: TI_SN74AHC244PWR; Second Source allowed: Yes; Symbol Type: Octal Buffers/Drivers With 3-State Outputs; Version Number: V01; Voltage DC (max): na; Article Number: 408890; Component Kind: Standard; ComponentLink1Description: Vendor WebSite; ComponentLink1URL: http://www.ti.com; ComponentLink2Description: Datasheet; ComponentLink2URL: http://webnetprod.muc.infineon.com/PCBPortal_Prod/WorkFlows/AltiumComponent/StandardDocumentation?Versionid=408891; Manufacturer Order Number: SN74AHC244PWR; PackageReference: TSSOP-20 (PW); Part Type: Logic Device; PLMStatus: Active; Supplier 1: Farnell; Supplier 2: DigiKey; Supplier 3: Mouser; Supplier Part Number 1: 2436833; Supplier Part Number 2: 296-1097-2-ND; Supplier Part Number 3: 595-SN74AHC244PWR; Temperature max: 0; Temperature min: 0; Temperature Range: Military; Value: SN74AHC244PWR; Library Name: AURIX_Lite_Kit_V2.0.SCHLIB
U2 = 408900: Single Bus Buffer Gate With 3-State Output; Legacy PartNumber: IC02600553; Manufacturer: Texas Instruments; Manufacturer Component Status: Active; Automotive Qualified: No; ComponentStatus: Released; Legacy DeviceID: TI_SN74LVC1G125DBVR; Second Source allowed: Yes; Version Number: V01; Voltage DC (max): na; Article Number: 408900; Component Kind: Standard; ComponentLink1Description: Vendor WebSite; ComponentLink1URL: http://www.ti.com; ComponentLink2Description: Datasheet; ComponentLink2URL: http://webnetprod.muc.infineon.com/PCBPortal_Prod/WorkFlows/AltiumComponent/StandardDocumentation?Versionid=408901; Manufacturer Order Number: SN74LVC1G125DBVR; PackageReference: SOT23-5 (DBV); Part Type: Logic Device; PLMStatus: Active; Supplier 1: Farnell; Supplier 2: DigiKey; Supplier 3: Mouser; Supplier Part Number 1: 2437138; Supplier Part Number 2: 296-11603-2-ND; Supplier Part Number 3: 595-SN74LVC1G125DBVR; Symbol Type: Single Bus Buffer Gate With 3-State Output; Temperature max: 0; Temperature min: 0; Temperature Range: Military; Value: SN74LVC1G125DBVR; Library Name: AURIX_Lite_Kit_V2.0.SCHLIB
U3 U4 = 409515: Single-Bit Dual-Supply Bus Transceiver with Configurable Voltage Translation and 3-State Outputs (Operating Temp -40Â°C to 85Â°C); Legacy PartNumber: IC02600608; Manufacturer: Texas Instruments; Manufacturer Component Status: Active; Automotive Qualified: No; Legacy DeviceID: TI_SN74LVC1T45DBV; Second Source allowed: Yes; Version Number: V01; Voltage DC (max): na; Article Number: 409515; Component Kind: Standard; ComponentLink1Description: Vendor WebSite; ComponentLink1URL: http://www.ti.com; ComponentLink2Description: Datasheet; ComponentLink2URL: http://webnetprod.muc.infineon.com/PCBPortal_Prod/WorkFlows/AltiumComponent/StandardDocumentation?Versionid=409516; Manufacturer Order Number: SN74LVC1T45DBVR; PackageReference: SOT23-6; Part Type: Logic Device; PLMStatus: Active; Supplier 1: Farnell; Supplier 2: DigiKey; Supplier 3: Mouser; Supplier Part Number 1: 2437195; Supplier Part Number 2: 296-16843-2-ND; Supplier Part Number 3: 595-SN74LVC1T45DBVR; Symbol Type: Single-Bit Dual-Supply Bus Transceiver with Configurable Voltage Translation and 3-State Outputs; Temperature Range: Military; Value: SN74LVC1T45DBVR; Library Name: AURIX_Lite_Kit_V2.0.SCHLIB
U5 = 409630: 1K Microwire Compatible Serial EEPROM; Legacy PartNumber: IC02600617; Manufacturer: Microchip Technology; Manufacturer Component Status: Active; Automotive Qualified: No; Legacy DeviceID: MT_93LC46B-I/SN; Second Source allowed: Yes; Version Number: V01; Voltage DC (max): na; Article Number: 409630; Component Kind: Standard; ComponentLink1Description: Vendor WebSite; ComponentLink1URL: http://www.microchip.com/; ComponentLink2Description: Datasheet; ComponentLink2URL: http://webnetprod.muc.infineon.com/PCBPortal_Prod/WorkFlows/AltiumComponent/StandardDocumentation?Versionid=409631; Manufacturer Order Number: 93LC46B-I/SN; PackageReference: SOIC-8; Part Type: Memory; PLMStatus: Active; Supplier 1: Farnell; Supplier 2: DigiKey; Supplier 3: Mouser; Supplier Part Number 1: 9758232; Supplier Part Number 2: 93LC46B-I/SN-ND; Supplier Part Number 3: 579-93LC46BISN; Symbol Type: 1K Microwire Compatible Serial EEPROM; Temperature Range: Military; Value: 93LC46B-I/SN; Library Name: AURIX_Lite_Kit_V2.0.SCHLIB
X4 = 386780: Micro-USB 2.0 Standard, Type AB, Bottom Mount, Shell SMT; Legacy PartNumber: CON01500945; Manufacturer: Hirose Connectors; Manufacturer Component Status: Active; Automotive Qualified: No; Combined Parameters: Board-to-Cable Socket (female);-x-;Mounting:SMT;Orient:90Â° (right angle);Shielded:Yes; Legacy DeviceID: HRS_ZX62-AB-5PA(31); Second Source allowed: Yes; Version Number: V01; Voltage DC (max): na; Application: Standard Interface; Article Number: 386780; Component Kind: Standard; ComponentLink1Description: Vendor WebSite; ComponentLink1URL: http://www.hirose.com/; ComponentLink2Description: Datasheet; ComponentLink2URL: http://webnetprod.muc.infineon.com/pcbportal_prod/WorkFlows/AltiumComponent/StandardDocumentation?Versionid=386781; Manufacturer Order Number: ZX62-AB-5PA(31); Package Description: SMT, Micro USB Type AB, Right Angle, 5 pins, 8.8mm L X 5.6mm W X 2.8mm H body; PLMStatus: Active; RoHS Compliant: Y; Supplier 1: Farnell; Supplier 2: DigiKey; Supplier 3: Mouser; Supplier Part Number 1: 2663341; Supplier Part Number 2: H125279TR-ND; Supplier Part Number 3: 798-ZX62-AB-5PA31; Temperature max: 85; Temperature min: -30; Temperature Range: Military; Type: Board-to-Cable; Value: ZX62-AB-5PA(31); Library Name: AURIX_Lite_Kit_V2.0.SCHLIB
Y1 = 409657: SMD Crystal Unit for Automotive Application, 12.000MHz; Legacy PartNumber: IC02600619; Manufacturer: Nihon Dempa Kogyo; Manufacturer Component Status: Active; Manufacturer Order Number: NX3225SA-12.000M-STD-CRS-2; Automotive Qualified: AEC Q200; Combined Parameters: Standard Standard Crystal;Tol.:-50%/+50%; ComponentStatus: Released; Legacy DeviceID: NDK_NX3225SA-12.000M-STD-CRS-2; QuickPick: 12000000 / Crystal; Second Source allowed: Yes; Style: Standard; Symbol type: Standard Crystal Symbol, 4 Pins; Tolerance Neg: 50%; Version Number: V01; Voltage DC (max): na; Application: Standard; Article Number: 409657; Component Kind: Standard; ComponentLink1Description: Vendor WebSite; ComponentLink1URL: http://www.ndk.com/en/; ComponentLink2Description: Datasheet; ComponentLink2URL: http://webnetprod.muc.infineon.com/PCBPortal_Prod/WorkFlows/AltiumComponent/StandardDocumentation?Versionid=409658; Package Description: Crystal; 3.2mm W X 2.5mm L X 0.6mm H body; PackageReference: SMD 3.2 x 2.5 x 0.6mm; Part Type: Clock; PLMStatus: Active; ROHS Compliant: Y; Supplier 1: Farnell; Supplier 2: DigiKey; Supplier 3: Mouser; Supplier Part Number 2: 644-1128-2-ND; Temperature max: 125; Temperature min: -40; Temperature Range: Automotive; Tolerance Pos: 50%; Type: Crystal; Value: 12MHz; Library Name: AURIX_Lite_Kit_V2.0.SCHLIB
